# Altium schematic sheet eRatPlatform_DriveSystem.SchDoc
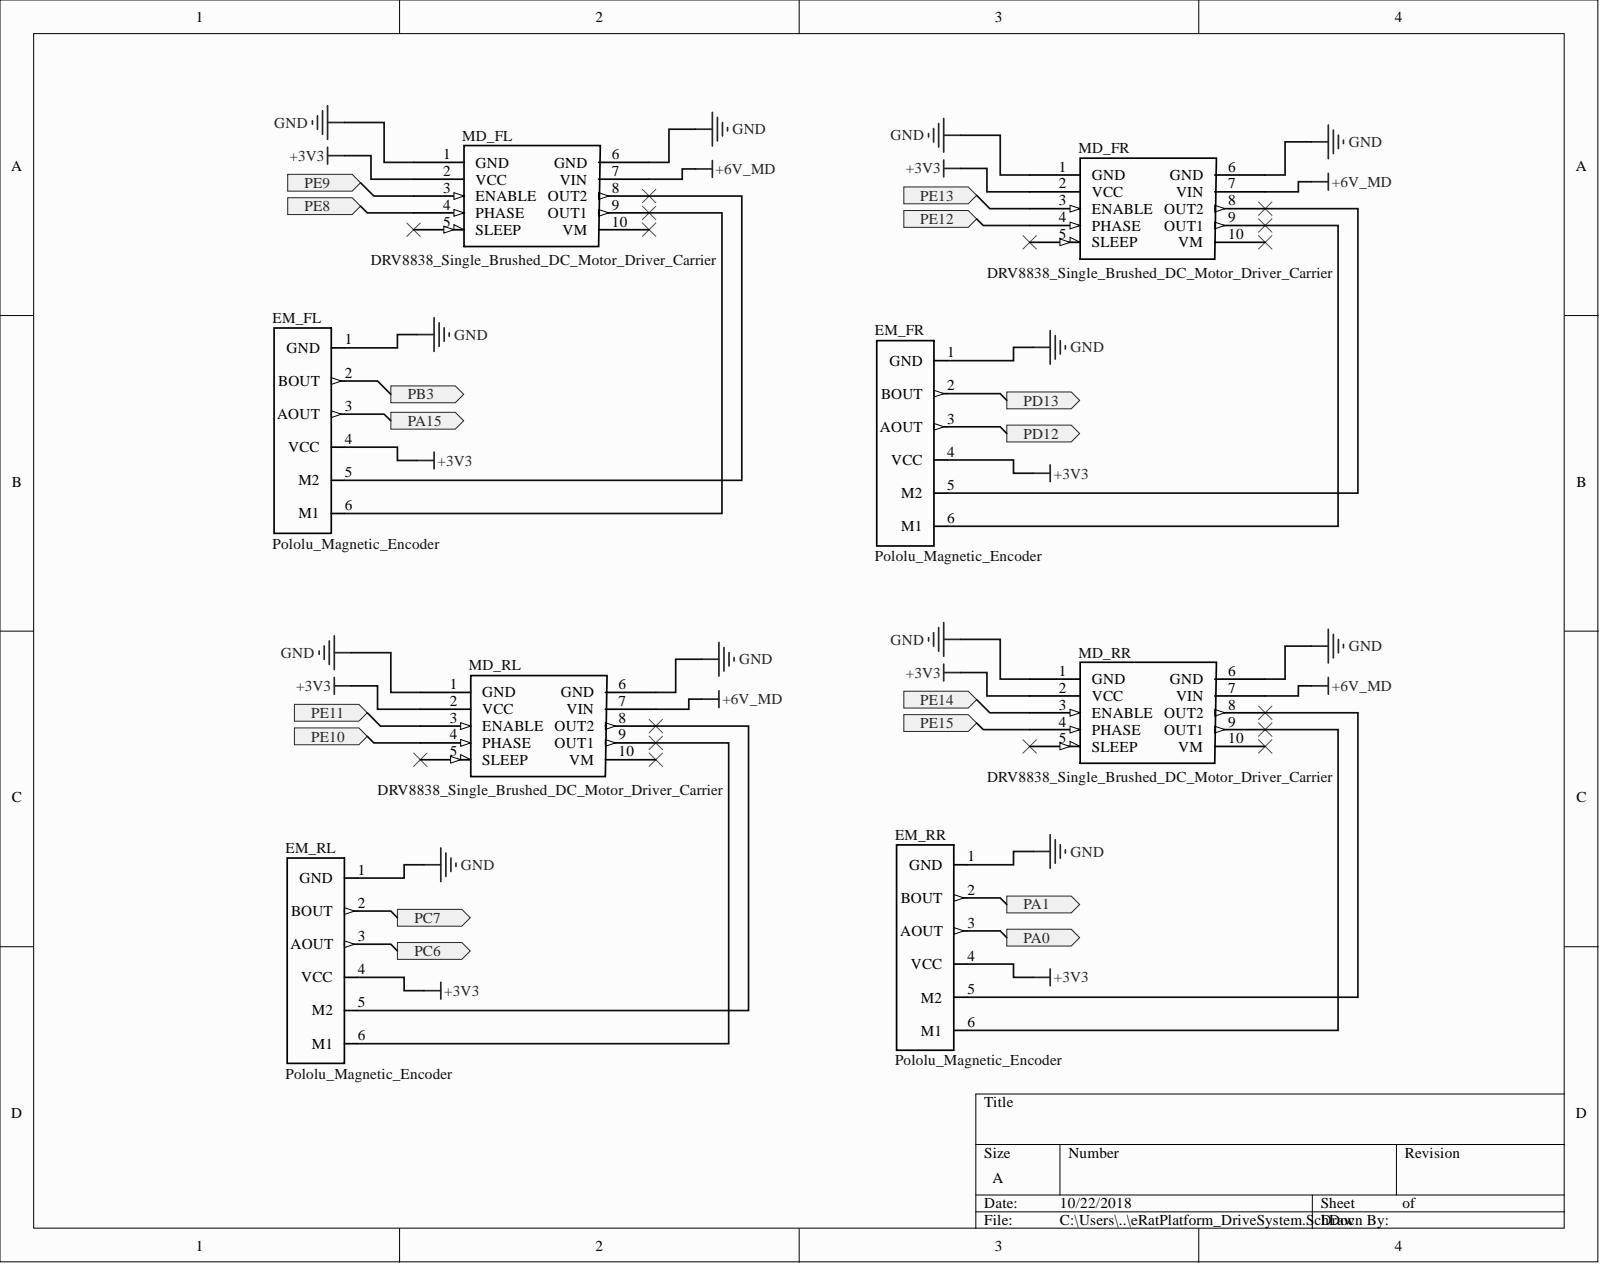
[diagram p0: eRatPlatform_DriveSystem.SchDoc]

## Parts
EM_FL  Pololu_Magnetic_Encoder  [665306124022]
EM_FR  Pololu_Magnetic_Encoder  [665306124022]
EM_RL  Pololu_Magnetic_Encoder  [665306124022]
EM_RR  Pololu_Magnetic_Encoder  [665306124022]
MD_FL  DRV8838_Single_Brushed_DC_Motor_Driver_Carrier  [DRV8838_Single_Brushed_DC_Motor_Driver_Carrier]
MD_FR  DRV8838_Single_Brushed_DC_Motor_Driver_Carrier  [DRV8838_Single_Brushed_DC_Motor_Driver_Carrier]
MD_RL  DRV8838_Single_Brushed_DC_Motor_Driver_Carrier  [DRV8838_Single_Brushed_DC_Motor_Driver_Carrier]
MD_RR  DRV8838_Single_Brushed_DC_Motor_Driver_Carrier  [DRV8838_Single_Brushed_DC_Motor_Driver_Carrier]

## Ports
PA0, PA1, PA15, PB3, PC6, PC7, PD12, PD13, PE8, PE9, PE10, PE11, PE12, PE13, PE14, PE15

## Nets
+3V3: EM_FL.4(VCC) EM_FR.4(VCC) EM_RL.4(VCC) EM_RR.4(VCC) MD_FL.2(VCC) MD_FR.2(VCC) MD_RL.2(VCC) MD_RR.2(VCC)
+6V_MD: MD_FL.7(VIN) MD_FR.7(VIN) MD_RL.7(VIN) MD_RR.7(VIN)
GND: EM_FL.1(GND) EM_FR.1(GND) EM_RL.1(GND) EM_RR.1(GND) MD_FL.1(GND) MD_FL.6(GND) MD_FR.1(GND) MD_FR.6(GND) MD_RL.1(GND) MD_RL.6(GND) MD_RR.1(GND) MD_RR.6(GND)
PA0: EM_RR.3(AOUT) ; port PA0
PA1: EM_RR.2(BOUT) ; port PA1
PA15: EM_FL.3(AOUT) ; port PA15
PB3: EM_FL.2(BOUT) ; port PB3
PC6: EM_RL.3(AOUT) ; port PC6
PC7: EM_RL.2(BOUT) ; port PC7
PD12: EM_FR.3(AOUT) ; port PD12
PD13: EM_FR.2(BOUT) ; port PD13
PE8: MD_FL.4(PHASE) ; port PE8
PE9: MD_FL.3(ENABLE) ; port PE9
PE10: MD_RL.4(PHASE) ; port PE10
PE11: MD_RL.3(ENABLE) ; port PE11
PE12: MD_FR.4(PHASE) ; port PE12
PE13: MD_FR.3(ENABLE) ; port PE13
PE14: MD_RR.3(ENABLE) ; port PE14
PE15: MD_RR.4(PHASE) ; port PE15
NetEM_FL_5: EM_FL.5(M2) MD_FL.8(OUT2)
NetEM_FL_6: EM_FL.6(M1) MD_FL.9(OUT1)
NetEM_FR_5: EM_FR.5(M2) MD_FR.8(OUT2)
NetEM_FR_6: EM_FR.6(M1) MD_FR.9(OUT1)
NetEM_RL_5: EM_RL.5(M2) MD_RL.8(OUT2)
NetEM_RL_6: EM_RL.6(M1) MD_RL.9(OUT1)
NetEM_RR_5: EM_RR.5(M2) MD_RR.8(OUT2)
NetEM_RR_6: EM_RR.6(M1) MD_RR.9(OUT1)

Not connected: MD_FL 5(SLEEP) 10(VM); MD_FR 5(SLEEP) 10(VM); MD_RL 5(SLEEP) 10(VM); MD_RR 5(SLEEP) 10(VM)

## Library data of the parts
EM_FL EM_FR EM_RL EM_RR = Pololu_Magnetic_Encoder: Component Kind: Standard; Library Name: Pololu_Magnetic_Encoder.IntLib
MD_FL MD_FR MD_RL MD_RR = DRV8838_Single_Brushed_DC_Motor_Driver_Carrier: Component Kind: Standard; Library Name: DRV8838_Single_Brushed_DC_Motor_Driver_Carrier.IntLib
